# Altium schematic sheet Offset_Selector.SchDoc(Offset_selector0)
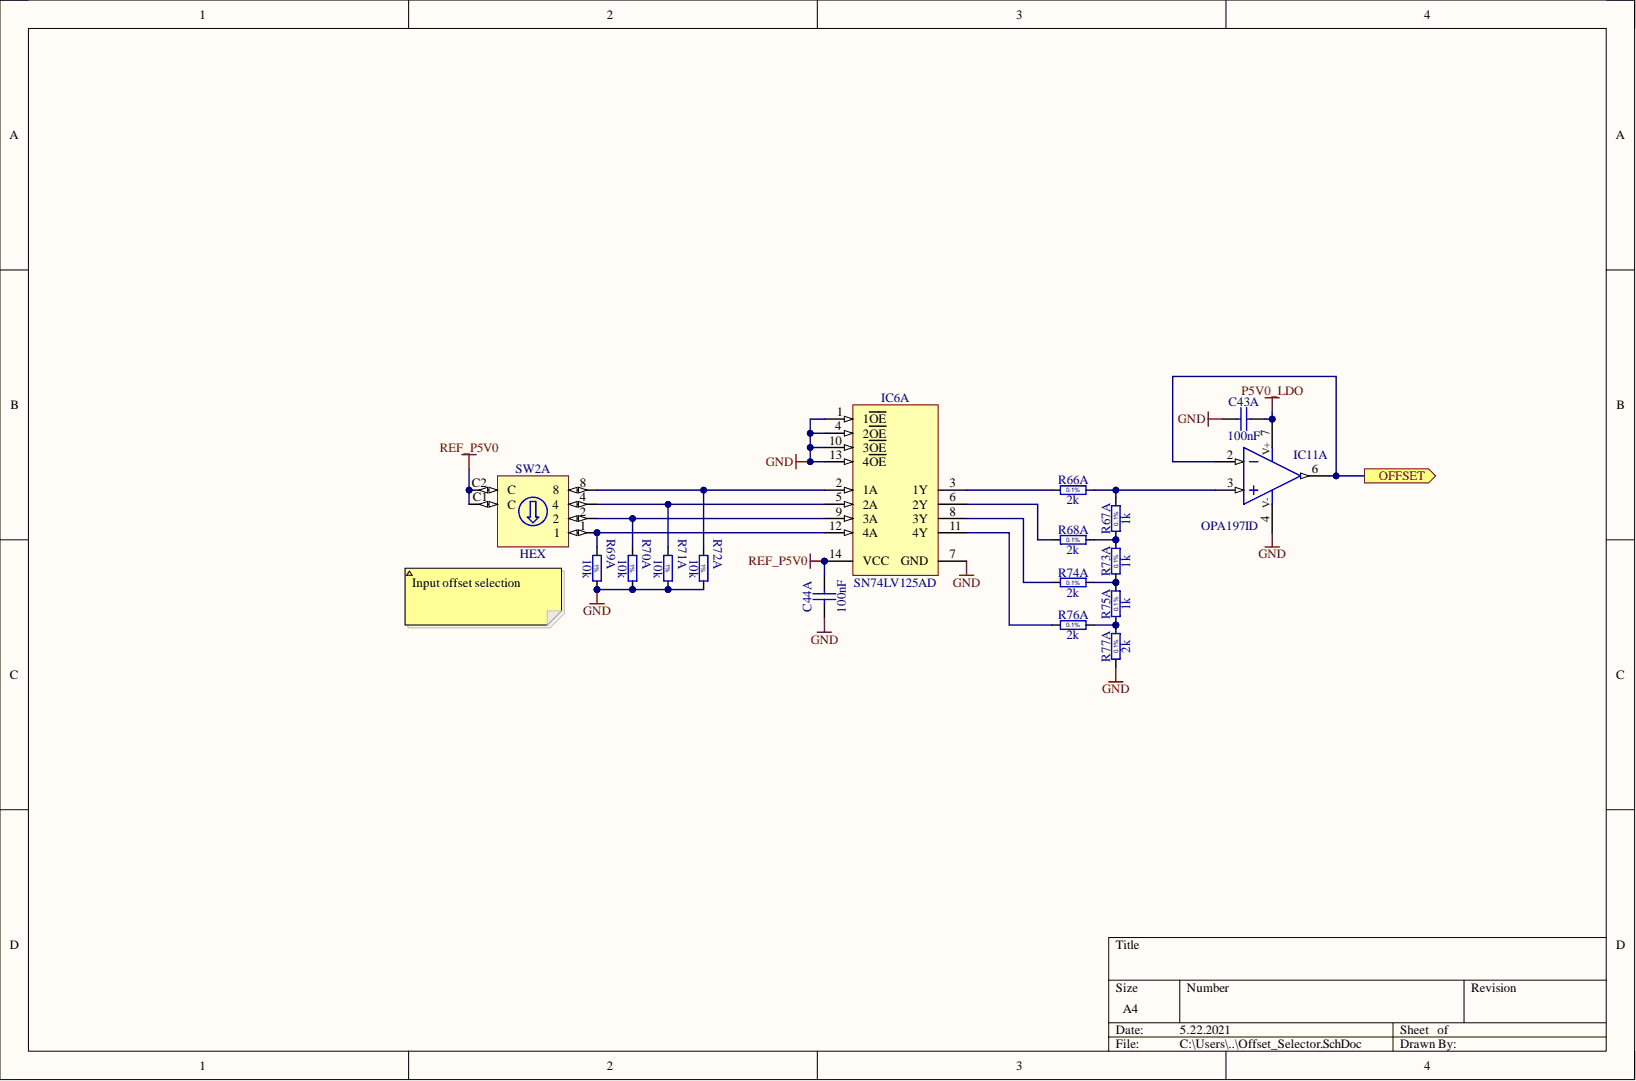
[diagram p0: Offset_Selector.SchDoc]

## Parts
C43A  100nF  [CAPC1608X87N]
C44A  100nF  [CAPC1608X87N]
IC6A  SN74LV125AD  [SOIC127P600X175-14N]
IC11A  OPA197ID  [SOIC127P600X175-8N]
R66A  2k  [RESC1608X55N]
R67A  1k  [RESC1608X55N]
R68A  2k  [RESC1608X55N]
R69A  10k  [RESC1608X55N]
R70A  10k  [RESC1608X55N]
R71A  10k  [RESC1608X55N]
R72A  10k  [RESC1608X55N]
R73A  1k  [RESC1608X55N]
R74A  2k  [RESC1608X55N]
R75A  1k  [RESC1608X55N]
R76A  2k  [RESC1608X55N]
R77A  2k  [RESC1608X55N]
SW2A  HEX  [SW_APEM_PT65106L254]

## Ports
OFFSETA

## Nets
GND: C43A.1 C44A.1 IC6A.1(1OE) IC6A.4(2OE) IC6A.7(GND) IC6A.10(3OE) IC6A.13(4OE) IC11A.4 R69A.2 R70A.2 R71A.2 R72A.2 R77A.1
P5V0_LDO: C43A.2 IC11A.7
REF_P5V0: C44A.2 IC6A.14(VCC) SW2A.C1(C) SW2A.C2(C)
NetIC6A_2: IC6A.2(1A) R72A.1 SW2A.8
NetIC6A_3: IC6A.3(1Y) R66A.1
NetIC6A_5: IC6A.5(2A) R71A.1 SW2A.4
NetIC6A_6: IC6A.6(2Y) R68A.1
NetIC6A_8: IC6A.8(3Y) R74A.1
NetIC6A_9: IC6A.9(3A) R70A.1 SW2A.2
NetIC6A_11: IC6A.11(4Y) R76A.1
NetIC6A_12: IC6A.12(4A) R69A.1 SW2A.1
NetIC11A_2: IC11A.2 IC11A.6
NetIC11A_3: IC11A.3 R66A.2 R67A.2
NetR67A_1: R67A.1 R68A.2 R73A.2
NetR73A_1: R73A.1 R74A.2 R75A.2
NetR75A_1: R75A.1 R76A.2 R77A.2

## Library data of the parts
C43A C44A = CC0603_100NF_50V_10%_X7R: Non-polarised capacitor; Manufacturer: GENERIC; Manufacturer1 Part Number: 223858615649; Part Description: SMD Multilayer Chip Ceramic Capacitor; Database Table Name: Capacitors SMD; TC: X7R; Tolerance: ±10%; Voltage: 50V; Case: 0603; Component Kind: Standard; Component Type: Standard; CreateDate: 03/12/2007; HelpURL: \\cern.ch\dfs\Applications\Altium\Datasheets\CC0603_X7R_PHYCOMP.pdf; LatestRevisionDate: 03/12/2007; Library Name: Capacitors.DbLib; Manufacturer1 ComponentHeight: 0.87mm; Manufacturer1 Example: PHYCOMP; Manufacturer Part Number: CC0603_100NF_50V_10%_X7R; Mounted: Yes; Part Number: CC0603_100NF_50V_10%_X7R; Pin Count: 2; Sense: No; SMD: Yes; Socket: No; Status: Preferred; Value: 100nF; Author: CERN DEM JLC
IC6A = SN74LV125AD: Quadruple Bus Buffer with 3-State Outputs; Manufacturer: TEXAS INSTRUMENTS; Bonding: No; Database Table Name: Standard Logic; PressFit: No; Case: SOIC14; Component Kind: Standard; Component Type: Standard; ComponentHeight: 1.75mm; CreateDate: 09.03.2011; Device: SN74LV125AD; HelpURL: \\cern.ch\dfs\Applications\Altium\Datasheets\SN74LV125AD.pdf; LatestRevisionDate: 09.03.2011; Library Name: ICs And Semiconductors.DbLib; Manufacturer Part Number: SN74LV125AD; Mounted: Yes; PackageDescription: SOIC 14, Pitch 1.27mm - Body 4x8.75mm, IPC Medium Density; Part Description: Quadruple Bus Buffer with 3-State Outputs; Part Number: SN74LV125AD; Pin Count: 14; Sense: No; SMD: Yes; Socket: No; Author: CERN DEM JLC
IC11A = OPA197ID: Operational Amplifier; Manufacturer: TEXAS INSTRUMENTS; Part Description: 36-V, Precision, Rail-to-Rail Input/Output, Low Offset Voltage, Operational Amplifiers; Bonding: No; Database Table Name: Operational Amplifiers; PressFit: No; Case: SOIC8; Component Kind: Standard; Component Type: Standard; ComponentHeight: 1.75mm; CreateDate: 30/06/2017; Device: OPA197ID; HelpURL: \\cern.ch\dfs\Applications\Altium\Datasheets\OPA197ID.pdf; LatestRevisionDate: 30/06/2017; Library Name: ICs And Semiconductors.DbLib; Manufacturer Part Number: OPA197ID; Mounted: Yes; PackageDescription: SOIC 8, Pitch 1.27mm - Body 4x5mm, IPC Medium Density; Part Number: OPA197ID; Pin Count: 8; Sense: No; SMD: Yes; Socket: No; Author: CERN DEM MR
R66A R68A R74A R76A R77A = R0603_2K_0.1%_0.063W_10PPM: Resistor - 0.1%; Manufacturer: GENERIC; Manufacturer1 Part Number: RN73C1J2K0BTDF; Part Description: Thin Film Chip Resistor; Database Table Name: Resistors SMD; Power: 0.063W; PressFit: No; TC: ±10ppm; Case: 0603; Component Kind: Standard; Component Type: Standard; CreateDate: 03.12.2007; HelpURL: \\cern.ch\dfs\Applications\Altium\Datasheets\R0603_Tyco_RN73.pdf; LatestRevisionDate: 13.03.2008; Library Name: Resistors.DbLib; Manufacturer1 ComponentHeight: 0.5mm; Manufacturer1 Example: TYCO HOLSWORTHY; Manufacturer Part Number: R0603_2K_0.1%_0.063W_10PPM; Mounted: Yes; Part Number: R0603_2K_0.1%_0.063W_10PPM; Pin Count: 2; Sense: No; SMD: Yes; Socket: No; Status: Not Recommended; Tolerance: ±0.1%; Value: 2k; Author: CERN DEM JLC
R67A R73A R75A = R0603_1K0_0.1%_0.063W_10PPM: Resistor - 0.1%; Manufacturer: GENERIC; Manufacturer1 Part Number: RN73C1J1K0BTDF; Part Description: Thin Film Chip Resistor; Database Table Name: Resistors SMD; Power: 0.063W; PressFit: No; TC: ±10ppm; Case: 0603; Component Kind: Standard; Component Type: Standard; CreateDate: 03.12.2007; HelpURL: \\cern.ch\dfs\Applications\Altium\Datasheets\R0603_Tyco_RN73.pdf; LatestRevisionDate: 13.03.2008; Library Name: Resistors.DbLib; Manufacturer1 ComponentHeight: 0.5mm; Manufacturer1 Example: TYCO HOLSWORTHY; Manufacturer Part Number: R0603_1K0_0.1%_0.063W_10PPM; Mounted: Yes; Part Number: R0603_1K0_0.1%_0.063W_10PPM; Pin Count: 2; Sense: No; SMD: Yes; Socket: No; Status: Not Recommended; Tolerance: ±0.1%; Value: 1k; Author: CERN DEM JLC
R69A R70A R71A R72A = R0603_10K_1%_0.1W_100PPM: Resistor - 1%; Manufacturer: GENERIC; Manufacturer1 Part Number: NRC06F1002TRF; Part Description: General Purpose Thick Film Chip Resistor; Database Table Name: Resistors SMD; Power: 0.1W; PressFit: No; TC: ±100ppm/°C; Case: 0603; Component Kind: Standard; Component Type: Standard; CreateDate: 03.12.2007; HelpURL: \\cern.ch\dfs\Applications\Altium\Datasheets\R0603_NIC_NRC.pdf; LatestRevisionDate: 13.03.2008; Library Name: Resistors.DbLib; Manufacturer1 ComponentHeight: 0.55mm; Manufacturer1 Example: NIC COMPONENT; Manufacturer Part Number: R0603_10K_1%_0.1W_100PPM; Mounted: Yes; Part Number: R0603_10K_1%_0.1W_100PPM; Pin Count: 2; Sense: No; SMD: Yes; Socket: No; Status: Preferred; Tolerance: ±1%; Value: 10k; Author: CERN DEM JLC
SW2A = SW_APEM_PT65106L254: Rotary Code Switch 1 2 4 8 C1 C2; Manufacturer: APEM; Part Description: Rotary Hexadecimal Right Angled Switch, Screwdriver Actuator, Straight terminals; Database Table Name: Switches; PressFit: No; Component Kind: Standard; Component Type: Standard; ComponentHeight: 10mm; CreateDate: 18.06.2014; Family: HEX; HelpURL: \\cern.ch\dfs\Applications\Altium\Datasheets\SW_APEM_PT65106L254.pdf; LatestRevisionDate: 18.06.2014; Library Name: Eletro-mechanical.DbLib; Manufacturer Part Number: PT65106L254; Mounted: Yes; PackageDescription: Body 10x10mm, Right Angled Rotary Hexadecimal Code Switch With Screwdriver Actuator, APEM PT65 (CR65) Series; Part Number: SW_APEM_PT65106L254; Pin Count: 6; Sense: No; SMD: No; Socket: No; Status: None; Author: CERN DEM JLC
